# Altium schematic sheet Christmas.SchDoc
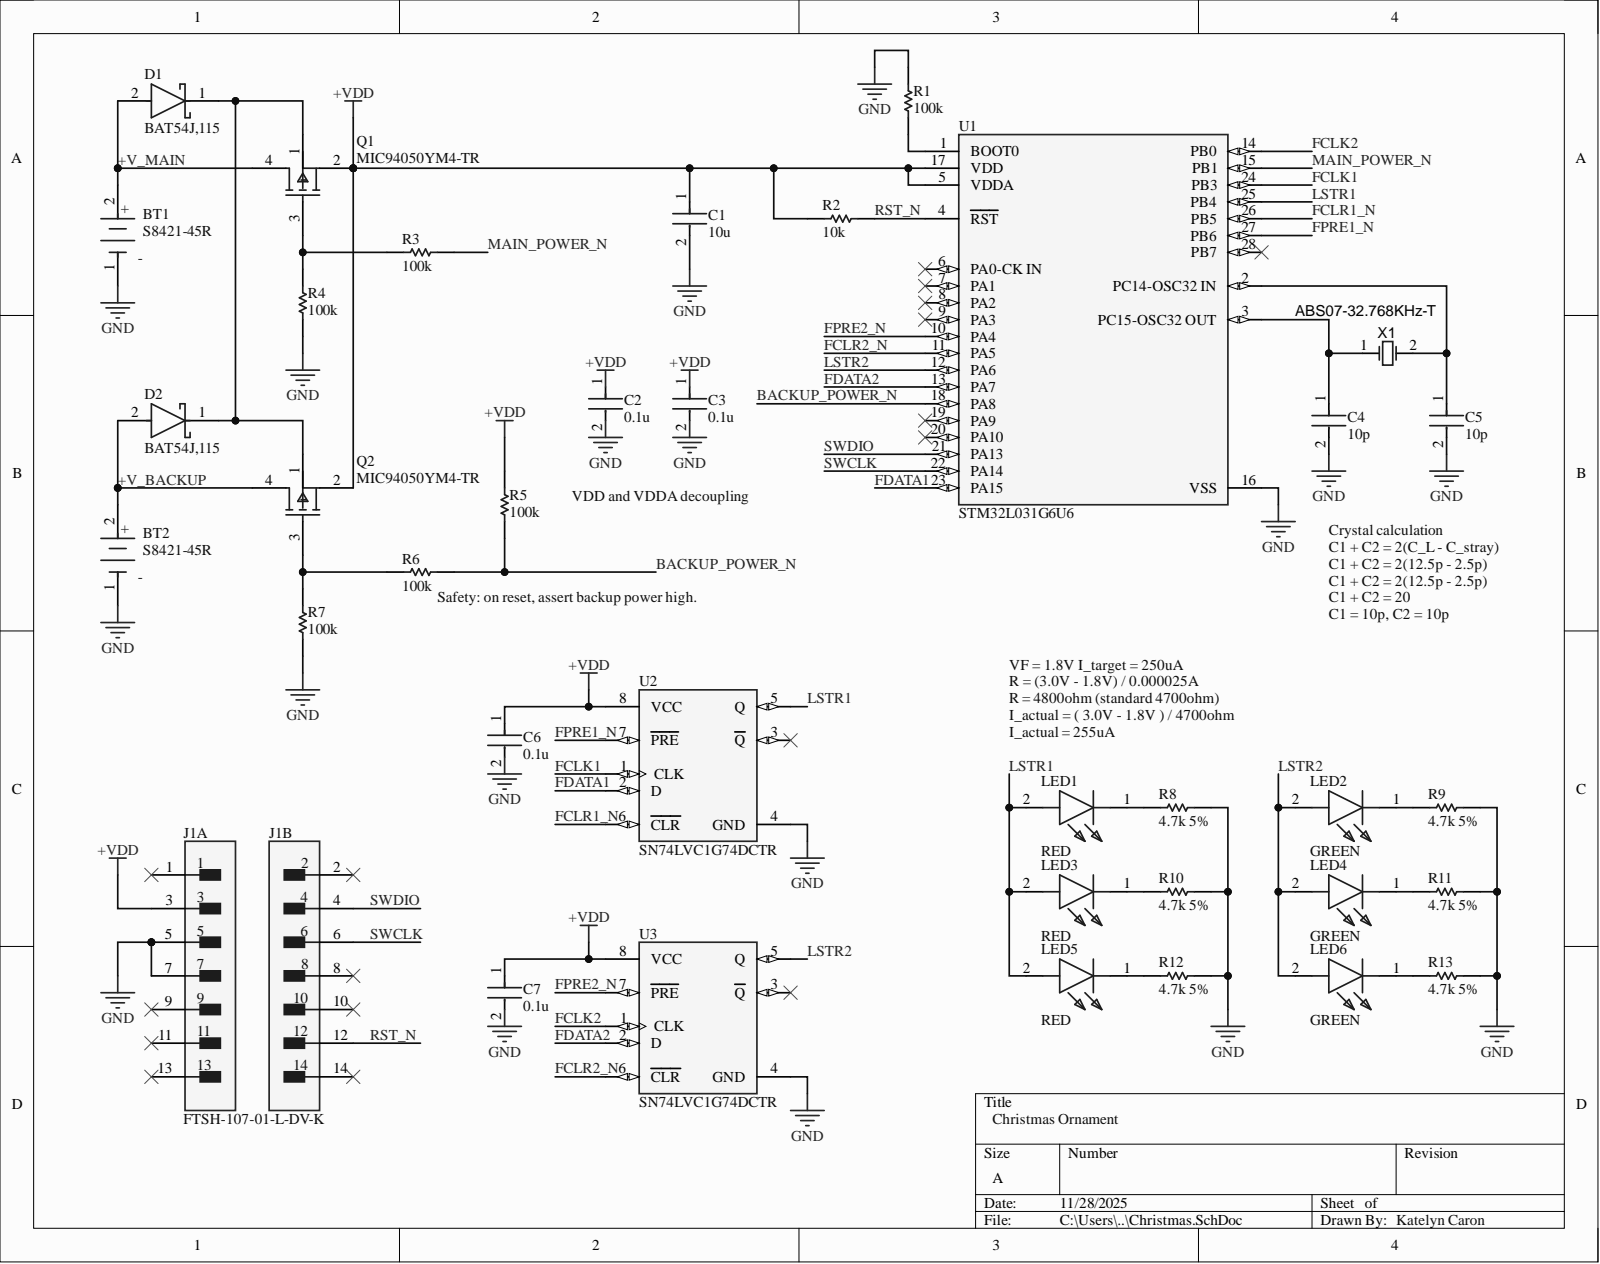
[diagram p0: Christmas.SchDoc]

## Parts
BT1  S8421-45R  [FP-S8421-45R-MFG]
BT2  S8421-45R  [FP-S8421-45R-MFG]
C1  10u  [FP-GRJ155-0_2-MFG]
C2  0.1u  [FP-C0402-BB-MFG]
C3  0.1u  [FP-C0402-BB-MFG]
C4  10p  [FP-C0402-BB-MFG]
C5  10p  [FP-C0402-BB-MFG]
C6  0.1u  [FP-C0402-BB-MFG]
C7  0.1u  [FP-C0402-BB-MFG]
D1  BAT54J,115  [FP-SOD323F-MFG]
D2  BAT54J,115  [FP-SOD323F-MFG]
J1  FTSH-107-01-L-DV-K  [FP-FTSH-107-01-L-DV-K-MFG]  (parts A,B)
LED1  RED  [LEDC1608X65N]
LED2  GREEN  [CSL1901MW1]
LED3  RED  [LEDC1608X65N]
LED4  GREEN  [CSL1901MW1]
LED5  RED  [LEDC1608X65N]
LED6  GREEN  [CSL1901MW1]
Q1  MIC94050YM4-TR  [FP-SOT143-4LD-PL-1-MFG]
Q2  MIC94050YM4-TR  [FP-SOT143-4LD-PL-1-MFG]
R1  100k  [RESC1005X40X25NL05T05]
R2  10k  [RESC1005X40X25LL10T10]
R3  100k  [RESC1005X40X25NL05T05]
R4  100k  [RESC1005X40X25NL05T05]
R5  100k  [RESC1005X40X25NL05T05]
R6  100k  [RESC1005X40X25NL05T05]
R7  100k  [RESC1005X40X25NL05T05]
R8  4.7k 5%  [RESC1005X40X25LL10T10]
R9  4.7k 5%  [RESC1005X40X25LL10T10]
R10  4.7k 5%  [RESC1005X40X25LL10T10]
R11  4.7k 5%  [RESC1005X40X25LL10T10]
R12  4.7k 5%  [RESC1005X40X25LL10T10]
R13  4.7k 5%  [RESC1005X40X25LL10T10]
U1  STM32L031G6U6  [FP-UFQFPN28-A0B0-MFG]
U2  SN74LVC1G74DCTR  [FP-DCT0008A-MFG]
U3  SN74LVC1G74DCTR  [FP-DCT0008A-MFG]
X1  ABS07-32.768KHz-T  [ABRA-ABS07-2_V]

## Nets
+VDD: C1.1 C2.1 C3.1 C6.1 C7.1 J1.3 Q1.2 Q2.2 R2.1 R5.1 U1.5(VDDA) U1.17(VDD) U2.8(VCC) U3.8(VCC)
GND: BT1.1 BT2.1 C1.2 C2.2 C3.2 C4.2 C5.2 C6.2 C7.2 J1.5 J1.7 R1.1 R4.1 R7.1 R8.1 R9.1 R10.1 R11.1 R12.1 R13.1 U1.16(VSS) U2.4(GND) U3.4(GND)
+V_BACKUP: BT2.2 D2.2 Q2.4
+V_MAIN: BT1.2 D1.2 Q1.4
BACKUP_POWER_N: R5.2 R6.1 U1.18(PA8)
FCLK1: U1.24(PB3) U2.1(CLK)
FCLK2: U1.14(PB0) U3.1(CLK)
FCLR1_N: U1.26(PB5) U2.6(CLR)
FCLR2_N: U1.11(PA5) U3.6(CLR)
FDATA1: U1.23(PA15) U2.2(D)
FDATA2: U1.13(PA7) U3.2(D)
FPRE1_N: U1.27(PB6) U2.7(PRE)
FPRE2_N: U1.10(PA4) U3.7(PRE)
LSTR1: LED1.2 LED3.2 LED5.2 U1.25(PB4) U2.5(Q)
LSTR2: LED2.2 LED4.2 LED6.2 U1.12(PA6) U3.5(Q)
MAIN_POWER_N: R3.1 U1.15(PB1)
RST_N: J1.12 R2.2 U1.4(RST)
SWCLK: J1.6 U1.22(PA14)
SWDIO: J1.4 U1.21(PA13)
NetC4_1: C4.1 U1.3(PC15-OSC32_OUT) X1.1
NetC5_1: C5.1 U1.2(PC14-OSC32_IN) X1.2
NetD1_1: D1.1 D2.1 Q1.1 Q2.1
NetLED1_1: LED1.1 R8.2
NetLED2_1: LED2.1 R9.2
NetLED3_1: LED3.1 R10.2
NetLED4_1: LED4.1 R11.2
NetLED5_1: LED5.1 R12.2
NetLED6_1: LED6.1 R13.2
NetQ1_3: Q1.3 R3.2 R4.2
NetQ2_3: Q2.3 R6.2 R7.2
NetR1_2: R1.2 U1.1(BOOT0)

Not connected: J1 1 2 8 9 10 11 13 14; U1 6(PA0-CK_IN) 7(PA1) 8(PA2) 9(PA3) 19(PA9) 20(PA10) 28(PB7); U2 3(Q); U3 3(Q)

## Library data of the parts
BT1 BT2 = CMP-2000-07028-3: BATTERY HOLDER COIN 20MM SMD; Number of Batteries: 1; Case/Package: SMD/SMT; Component Kind: Standard; Contact Plating: Bronze; Library Name: Altium Content Vault; Material: Polyphenylene; Max Operating Temperature: 105°C; Min Operating Temperature: -40°C; Mount: Surface Mount; Number of Cells: 1; Package Quantity: 500; Packaging: Tape and Reel; REACH SVHC: No SVHC; Termination: SMD/SMT
C1 = CMP-06035-062410-1: CAP CER 10UF 6.3V X5R 0402; Tolerance: 20%; Capacitance: 10uF; Case Code (Imperial): 0402; Case Code (Metric): 1005; Case/Package: 0402; Component Kind: Standard; Features: Soft Termination; Length: 0.039inch; Library Name: Altium Content Vault; Max Operating Temperature: 85°C; Min Operating Temperature: -55°C; Mount: Surface Mount; Packaging: Cut Tape; RoHS Compliant: Yes; Thickness: 0.028inch; Voltage Rating: 6.3V; Width: 0.02inch
C2 C3 C6 C7 = CMP-03020-002733-1: CAP CER 0.1UF 10V X7R 0402; Manufacturer: Kemet; Manufacturer Part Number: C0402C104K8RACAUTO; Capacitance: 0.10 μF; Material: Ceramic; Temperature Coefficient: 15%; Tolerance: 0.1; Value: 0.10 μF; Automotive: No; Category: Cap; Component Kind: Standard; ComponentLink2Description: Datasheet; ComponentLink2URL: https://content.kemet.com/datasheets/KEM_C1002_X7R_SMD.pdf; Device Class L1: Passive Components; Device Class L2: Capacitors; Device Class L3: Ceramic Capacitors; Digikey Description: CAP CER 0.1UF 10V X7R 0402; Height: 0.55mm; Ipc Land Pattern Name: CAPC10050X50; Lead Free: Yes; Library Name: Altium Content Vault; Octopart: https://octopart.com/c0402c104k8racauto-kemet-9145167; Package: C0402-BB; RoHS: TRUE; Temperature: -55°C to +125°C; Temperature Characteristic: X7R; Temperature Range High: +125°C; Temperature Range Low: -55°C; Voltage Rating: 10 V
C4 C5 = CMP-1034-00720-3: C0402 10 pF C0G 0.5 pF 50 V; Manufacturer: Kemet; Manufacturer Part Number: C0402C100D5GAC; Capacitance: 10 pF; Material: Ceramic; Tolerance: 0.5 pF; Value: 10 pF; Voltage Rating: 50 V; Automotive: No; Category: Cap; Component Kind: Standard; ComponentLink2Description: Datasheet; ComponentLink2URL: https://content.kemet.com/datasheets/KEM_C1002_X7R_SMD.pdf; Device Class L1: Passive Components; Device Class L2: Capacitors; Device Class L3: Ceramic Capacitors; Height: 0.55mm; Ipc Land Pattern Name: CAPC10050X50; Lead Free: Yes; Library Name: Altium Content Vault; Mouser Description: Cap Ceramic 10pF 50V C0G 0.5pF SMD 0402 125°C Bulk; Octopart: https://octopart.com/c0402c100d5gac-kemet-2680337; Package: C0402-BB; RoHS: TRUE; Temperature: -55°C to +125°C; Temperature Characteristic: C0G; Temperature Range High: +125°C; Temperature Range Low: -55°C
D1 D2 = CMP-14398-000037-1: DIODE SCHOTTKY 30V 200MA SOD323F; Component Kind: Standard; Library Name: Altium Content Vault; RoHS Compliant: Yes
J1 = CMP-02766-001412-1: CONN HEADER SMD 14POS 1.27MM; Gender: Male; Number of Contacts: 14; Component Kind: Standard; Contact Material: Bronze; Contact Plating: Gold; Depth: 5.84mm; Housing Color: Black; Housing Material: Polymer; Lead Free: Lead Free; Length: 8.89mm; Library Name: Altium Content Vault; Max Operating Temperature: 125°C; Min Operating Temperature: -55°C; Number of Rows: 2; Orientation: Straight; Packaging: Rail/Tube; Pitch: 1.27mm; Radiation Hardening: No; RoHS Compliant: Yes; Termination: Solder
LED1 LED3 LED5 = CSL1901VW1: LED; Manufacturer_Name: ROHM Semiconductor; Component Kind: Standard; Datasheet Link: https://fscdn.rohm.com/en/products/databook/datasheet/opto/led/chip_mono/csl1901vw1-e.pdf; Height: 0.65mm; Manufacturer_Part_Number: CSL1901VW1; Mouser Part Number: 755-CSL1901VW1; Mouser Price/Stock: https://www.mouser.co.uk/ProductDetail/ROHM-Semiconductor/CSL1901VW1?qs=vvQtp7zwQdNmrXZcE27xEA%3D%3D
LED2 LED4 LED6 = CSL1901MW1: LED; Manufacturer_Name: ROHM Semiconductor; Component Kind: Standard; Datasheet Link: https://fscdn.rohm.com/en/products/databook/datasheet/opto/led/chip_mono/csl1901mw1-e.pdf; Height: 0.65mm; Manufacturer_Part_Number: CSL1901MW1; Mouser Part Number: 755-CSL1901MW1; Mouser Price/Stock: https://www.mouser.co.uk/ProductDetail/ROHM-Semiconductor/CSL1901MW1?qs=vvQtp7zwQdN3uHEcX5W%252BnQ%3D%3D
Q1 Q2 = CMP-00252-66803773-1: MIC94050YM4-TR 1.8V-Rated Reverse-Blocking Pfet 4 Sot-143 - T/R Rohs Compliant: Yes; Continuous Drain Current (ID): 1.8A; Current Rating: -1.8A; Drain to Source Resistance: 320mΩ; Drain to Source Voltage (Vdss): 6V; Element Configuration: Single; Input Capacitance: 600pF; Max Power Dissipation: 568mW; Number of Elements: 1; Rds On Max: 160mΩ; Voltage Rating (DC): -6V; Case/Package: SOT-143; Component Kind: Standard; Gate to Source Voltage (Vgs): 6V; Height: 1.02mm; Lead Free: Lead Free; Length: 2.92mm; Library Name: Altium Content Vault; Lifecycle Status: Production; Max Operating Temperature: 150°C; Min Operating Temperature: -40°C; Mount: Surface Mount; NexarPartId: 66803773; Number of Pins: 4; Packaging: Tape & Reel (TR); Power Dissipation: 568mW; Resistance: 160mΩ; RoHS: Compliant; Schedule B: 8541210080, 8542390000, 8542390000; Width: 1.3mm
R1 R3-R7 = CMP-2002-08126-1: Manufacturer: Vishay Dale; Part Number: CRCW0402100KJNTD; Component Kind: Standard; Library Name: Altium Content Vault
R2 = CMP-2002-03714-1: Manufacturer: KOA Speer; Part Number: RK73B1ETTP103J; Component Kind: Standard; Library Name: Altium Content Vault
R8-R13 = CMP-2002-03706-1: Manufacturer: KOA Speer; Part Number: SG73S1ETTP472J; Component Kind: Standard; Library Name: Altium Content Vault
U1 = CMP-12105-000016-1: IC MCU 32BIT 32KB FLASH 28UFQFPN; Manufacturer: STMicroelectronics; Core Architecture: ARM Cortex-M0+; Data Bus Width: 32bits; Frequency: 32MHz; Interface: I2C,SPI,UART,USART,IrDA,SMBus; Max Junction Temp: +105°C; Max Supply Voltage: 3.6V; Memory Size: 32KBytes; Min Supply Voltage: 1.65V; Number Of A/D Converters: 1; Number Of Adc Channels: 10; Number Of I/Os: 21; Number Of Spi Channels: 3; Number Of Timers/Counters: 4; Number Of Uart Channels: 2; Ram Size: 8KBytes; Standoff Height: 0mm; Ambient Temperature Range High: +85°C; Ambient Temperature Range Low: -40°C; Automotive: No; Category: IC; Component Kind: Standard; ComponentLink2Description: Datasheet; ComponentLink2URL: https://www.st.com/resource/en/datasheet/stm32l031g6.pdf; Device Class L1: Integrated Circuits (ICs); Device Class L2: Embedded Processors and Controllers; Device Class L3: Microcontrollers; Digikey Description: IC MCU 32BIT 32KB FLASH 28UFQFPN; Height: 0.6mm; Lead Free: Yes; Library Name: Altium Content Vault; Manufacturer Part Number: STM32L031G6U6; Memory Type: FLASH; Mouser Description: ARM Microcontrollers - MCU Ultra-low-power ARM Cortex-M0+ MCU with 32-Kbytes Flash, 32 MHz CPU; Number Of Cores: 1; Number Of I2C Channels: 1; Octopart: https://octopart.com/stm32l031g6u6-stmicroelectronics-68582494; Package: UFQFPN28-A0B0; RoHS: TRUE; Temperature: -40°C to +105°C; Temperature Range High: +105°C; Temperature Range Low: -40°C
U2 U3 = CMP-0859-00550-4: IC FF D-TYPE SNGL 1BIT SM8; Manufacturer: Texas Instruments; Frequency: 200MHz; Logic Function Desc: D Type; Max Supply Voltage: 5.5V; Min Supply Voltage: 1.65V; Nominal Supply Current: 10uA; Number Of Circuits: 1; Number Of Outputs: 2; Propagation Delay: 6.4ns; Standoff Height: 0mm; Ambient Temperature Range High: +125°C; Ambient Temperature Range Low: -40°C; Automotive: No; Category: IC; Component Kind: Standard; ComponentLink2Description: Datasheet; ComponentLink2URL: http://www.ti.com/lit/ds/symlink/sn74lvc1g74.pdf; Device Class L1: Integrated Circuits (ICs); Device Class L2: Logic ICs; Device Class L3: Latches and Flip Flops; Digikey Description: IC FF D-TYPE SNGL 1BIT SM8; Height: 1.3mm; Ipc Land Pattern Name: SOP65P400X130-8; Lead Free: Yes; Library Name: Altium Content Vault; Manufacturer Part Number: SN74LVC1G74DCTR; Mouser Description: Flip Flops Sgl Pos-Edge-Trggrd; Number Of Inputs: 1; Octopart: https://octopart.com/sn74lvc1g74dctr-texas+instruments-12194016; Package: DCT0008A; RoHS: TRUE; Temperature: -40°C to +125°C; Temperature Range High: +125°C; Temperature Range Low: -40°C
X1 = CMP-003-00022-2: Low Profile Crystal, 32.768 kHz, +/- 20 ppm, 12.5 pF, -40 to 85 degC, 2-Pin SMD, Pb-Free, Tape and Reel; Manufacturer: Abracon; ESR: 70k; Frequency Tolerance: 20ppm; Load Capacitance: 12.5pF; Case/Package: CSMD; Component Kind: Standard; Datasheet URL: http://www.abracon.com/Resonators/ABS07.pdf; Datasheet Version: Aug-15; Frequency: 32.768kHz; Manufacturer URL: http://www.abracon.com/; Max Operating Temperature: 85°C; Min Operating Temperature: -40°C; Mounting Technology: SM; Package Description: 2-Pin Surface Mount Device, Body 3.2 x 1.5 mm; Package Quantity: 3000; Package Reference: ABS07-2; Package URL: http://www.abracon.com/Support/PackageDrawing/Resonators/ABS07.PDF; Packaging: TapeandReel; Pins: 2; RoHS Compliant: True; Tolerance: 20ppm; Library Name: Katelyn Caron
